# Altium schematic sheet wls2-photoelectric.SchDoc(wls2-photoelectric)
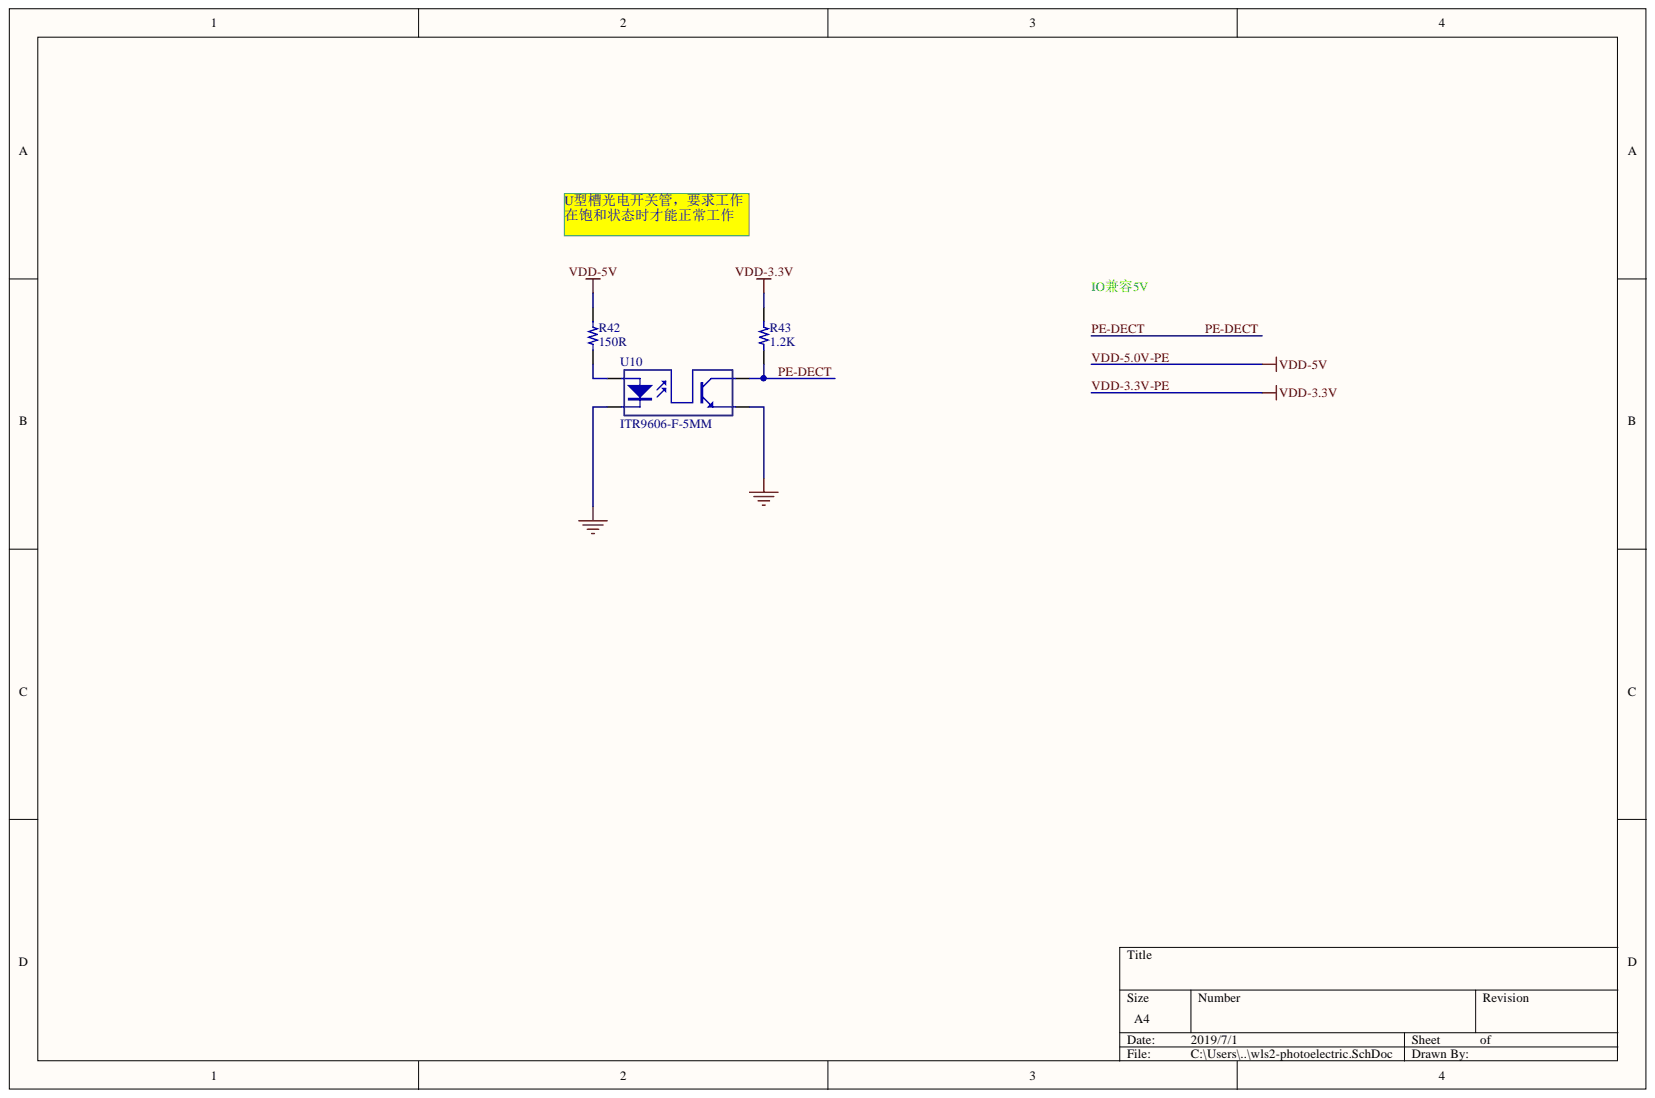
[diagram p0: wls2-photoelectric.SchDoc]

## Parts
R42  150R  [RES 0402/1005]
R43  1.2K  [RES 0402/1005]
U10  ITR9606-F-5MM  [IRF9702-F]

## Nets
GND: U10.2 U10.4
PE-DECT: R43.1 U10.3
VDD-3.3V-PE: R43.2
VDD-5.0V-PE: R42.1
NetR42_2: R42.2 U10.1

## Library data of the parts
R42 R43 = Resistor: SMT Resistor; Component Kind: Standard; Library Name: Passives.SchLib
  R42: Value: 150R
  R43: Value: 1.2K
U10 = Optoisolator1: 4-Pin Phototransistor Optocoupler; Component Kind: Standard; DatasheetVersion: Nov-2003; LatestRevisionDate: 04-Mar-2005; LatestRevisionNote: Sch pins 3 & 4 Swapped.; Library Name: Footprint.SchLib; PackageReference: DIP-4; Published: 24-Mar-1999; Publisher: Altium Limited
